# Altium schematic sheet Dynamixel.SchDoc(Dynamixel)
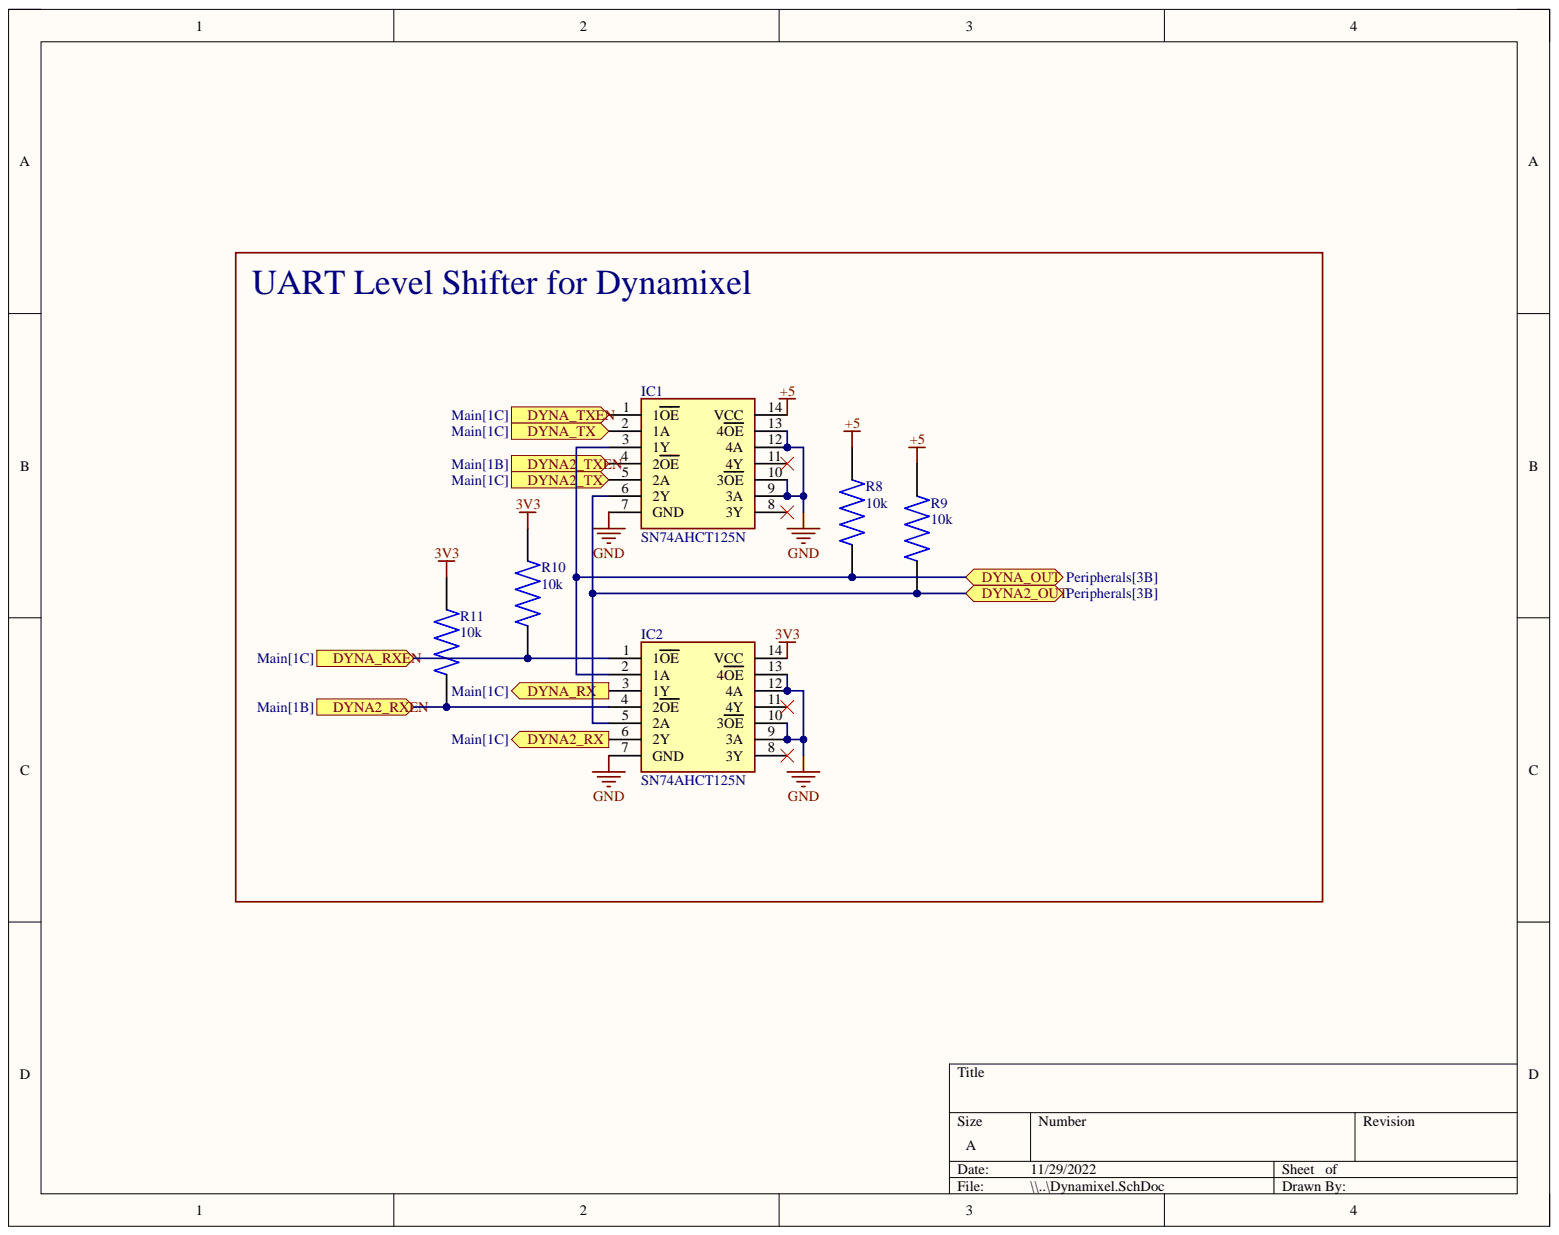
[diagram p0: Dynamixel.SchDoc]

## Parts
IC1  SN74AHCT125N  [DIP794W53P254L1930H508Q14N]
IC2  SN74AHCT125N  [DIP794W53P254L1930H508Q14N]
R8  10k  [RESC2012X55N]
R9  10k  [RESC2012X55N]
R10  10k  [RESC2012X55N]
R11  10k  [RESC2012X55N]

## Ports
DYNA2_OUT, DYNA2_RX, DYNA2_RXEN, DYNA2_TX, DYNA2_TXEN, DYNA_OUT, DYNA_RX, DYNA_RXEN, DYNA_TX, DYNA_TXEN

## Nets
3V3: IC2.14(VCC) R10.2 R11.2
+5: IC1.14(VCC) R8.2 R9.2
GND: IC1.7(GND) IC1.9(3A) IC1.10(3OE) IC1.12(4A) IC1.13(4OE) IC2.7(GND) IC2.9(3A) IC2.10(3OE) IC2.12(4A) IC2.13(4OE)
DYNA2_OUT: IC1.6(2Y) IC2.5(2A) R9.1 ; port DYNA2_OUT
DYNA2_RX: IC2.6(2Y) ; port DYNA2_RX
DYNA2_RXEN: IC2.4(2OE) R11.1 ; port DYNA2_RXEN
DYNA2_TX: IC1.5(2A) ; port DYNA2_TX
DYNA2_TXEN: IC1.4(2OE) ; port DYNA2_TXEN
DYNA_OUT: IC1.3(1Y) IC2.2(1A) R8.1 ; port DYNA_OUT
DYNA_RX: IC2.3(1Y) ; port DYNA_RX
DYNA_RXEN: IC2.1(1OE) R10.1 ; port DYNA_RXEN
DYNA_TX: IC1.2(1A) ; port DYNA_TX
DYNA_TXEN: IC1.1(1OE) ; port DYNA_TXEN

Not connected: IC1 8(3Y) 11(4Y); IC2 8(3Y) 11(4Y)

## Library data of the parts
IC1 IC2 = SN74AHCT125N: Integrated Circuit; Manufacturer_Name: Texas Instruments; Arrow Part Number: SN74AHCT125N; Arrow Price/Stock: https://www.arrow.com/en/products/sn74ahct125n/texas-instruments?region=nac; Component Kind: Standard; Datasheet Link: http://www.ti.com/lit/gpn/sn74ahct125; Height: 5.08mm; Manufacturer_Part_Number: SN74AHCT125N; Mouser Part Number: 595-SN74AHCT125N; Mouser Price/Stock: https://www.mouser.co.uk/ProductDetail/Texas-Instruments/SN74AHCT125N?qs=1wQRf3HkBjyxwrHMwAiMfw%3D%3D
R8-R11 = TNPW0805270RBETA: MF: {'manufacturer': u'Vishay'}; Availability: Unavailable; Component Kind: Standard; MP: TNPW0805270RBETA; Package: None; Price: None
